# Altium schematic sheet USB_HS.SchDoc
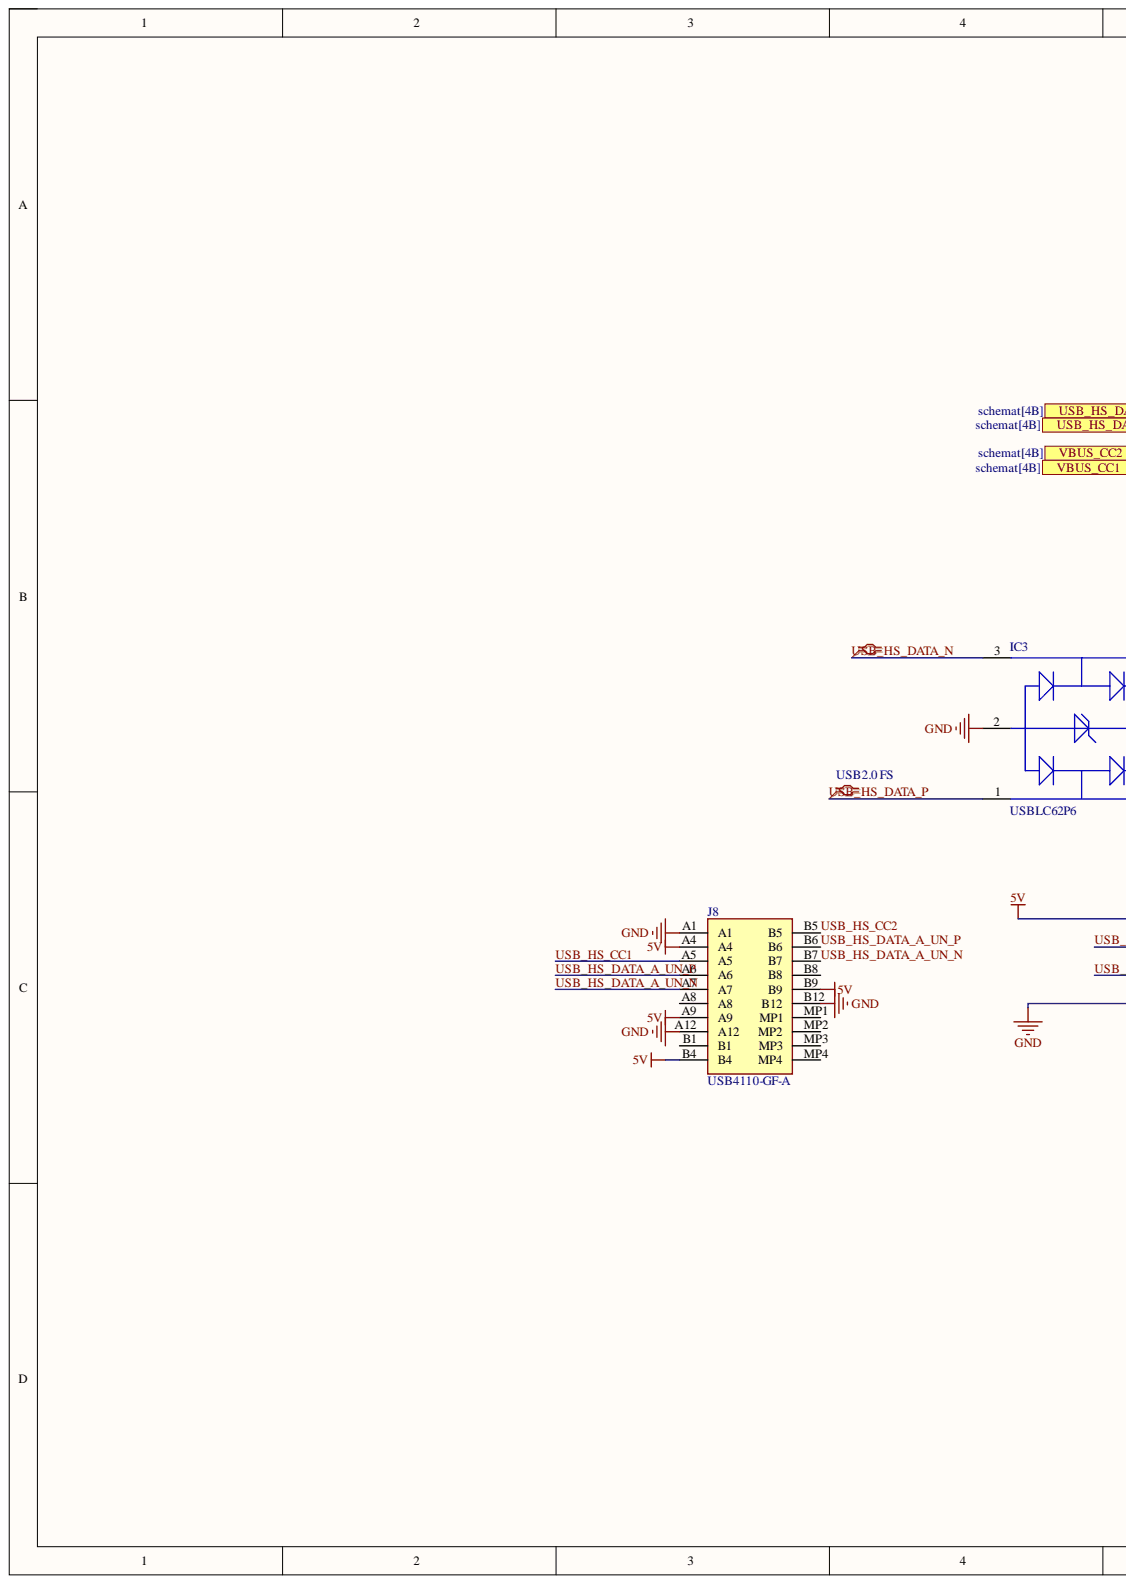
[diagram p0: USB_HS.SchDoc, left]
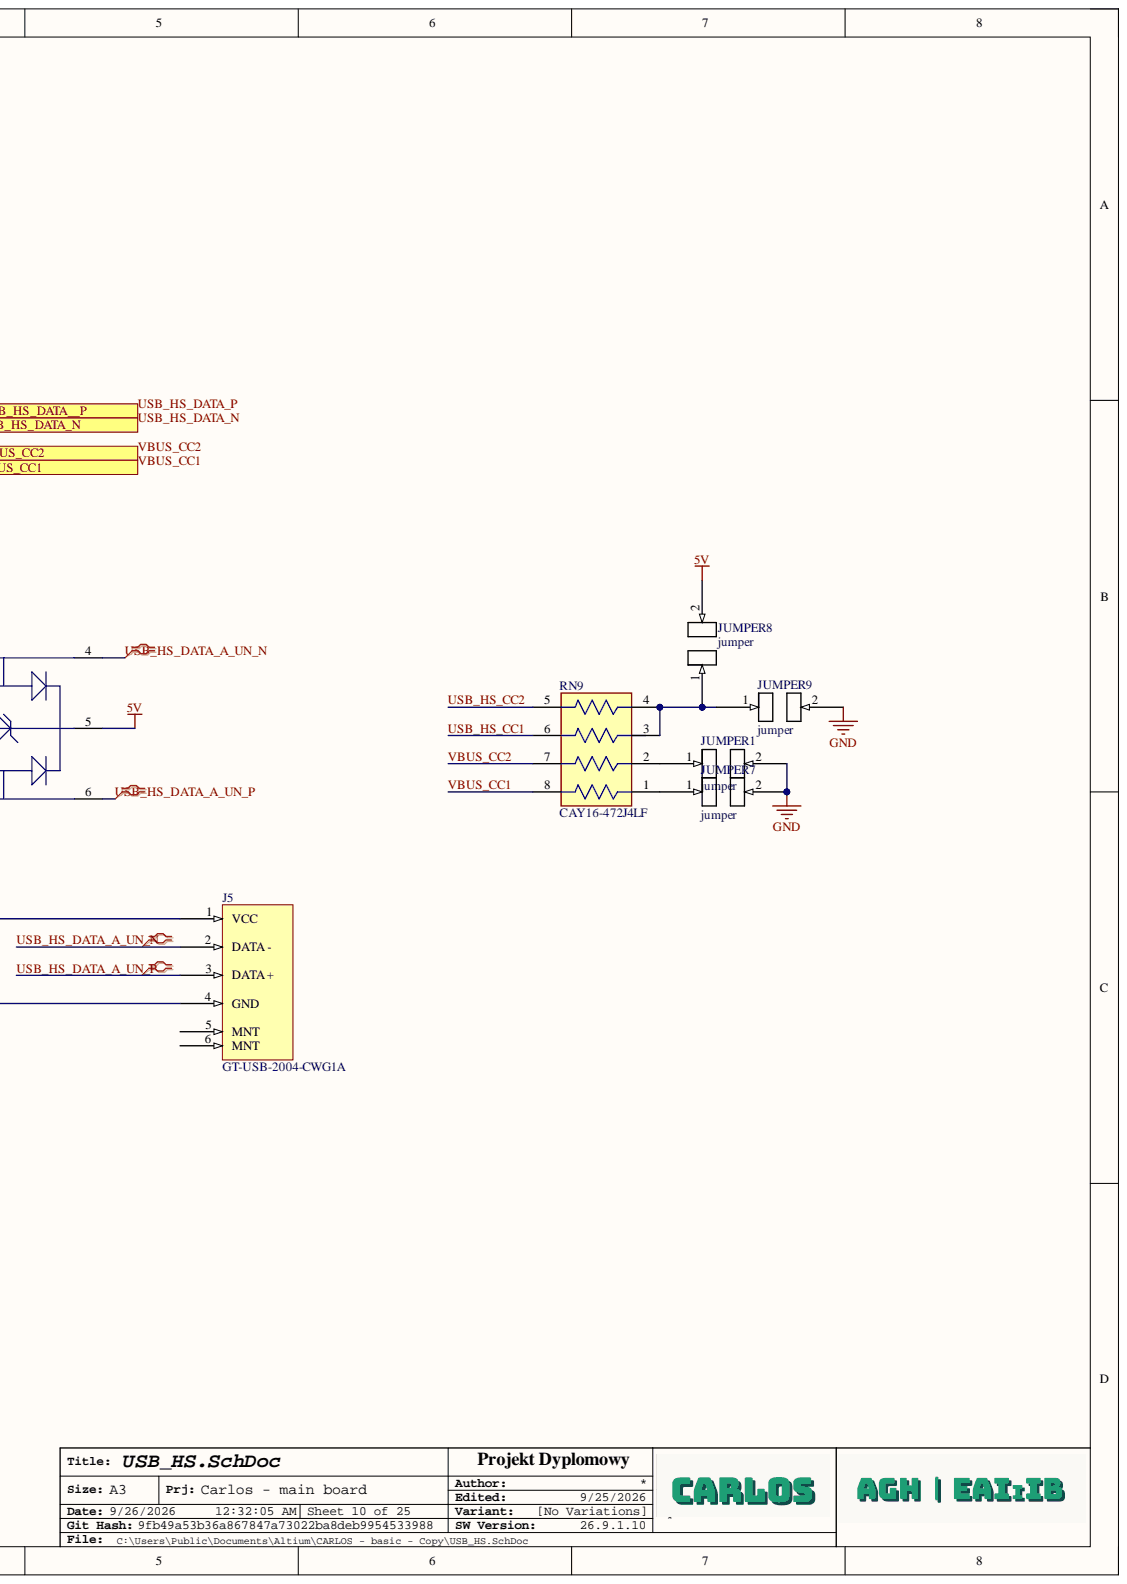
[diagram p1: USB_HS.SchDoc, right]

## Parts
IC3  USBLC62P6  [USBLC62P6]
J5  GT-USB-2004-CWG1A  [New footprint 1]
J8  USB4110-GF-A  [USB4110GFA]
JUMPER1  jumper  [jumper-Footprint-1]
JUMPER7  jumper  [jumper-Footprint-1]
JUMPER8  jumper  [jumper-Footprint-1]
JUMPER9  jumper  [jumper-Footprint-1]
RN9  CAY16-472J4LF  [FP-CAY16-J4-MFG]

## Ports
USB_HS_DATA__P, USB_HS_DATA_N, VBUS_CC1, VBUS_CC2

## Nets
5V: IC3.5 J5.1(VCC) J8.A4 J8.A9 J8.B4 J8.B9 JUMPER8.2
GND: IC3.2 J5.4(GND) J8.A1 J8.A12 J8.B12 JUMPER1.2 JUMPER7.2 JUMPER9.2
USB_HS_CC1: J8.A5 RN9.6
USB_HS_CC2: J8.B5 RN9.5
USB_HS_DATA_A_UN_N: IC3.4 J5.2(DATA_-) J8.A7 J8.B7
USB_HS_DATA_A_UN_P: IC3.6 J5.3(DATA_+) J8.A6 J8.B6
USB_HS_DATA_N: IC3.3 ; port USB_HS_DATA_N
USB_HS_DATA_P: IC3.1 ; port USB_HS_DATA__P
VBUS_CC1: RN9.8 ; port VBUS_CC1
VBUS_CC2: RN9.7 ; port VBUS_CC2
NetJUMPER1_1: JUMPER1.1 RN9.2
NetJUMPER7_1: JUMPER7.1 RN9.1
NetJUMPER8_1: JUMPER8.1 JUMPER9.1 RN9.3 RN9.4

Not connected: J5 5(MNT) 6(MNT); J8 A8 B1 B8 MP1 MP2 MP3 MP4

## Library data of the parts
IC3 = USBLC62P6: Integrated Circuit; Manufacturer_Name: STMicroelectronics; Component Kind: Standard; Datasheet Link: https://datasheet.lcsc.com/szlcsc/STMicroelectronics-USBLC6-2P6_C15999.pdf; Height: 0.6mm; Manufacturer_Part_Number: USBLC62P6
J5 = CMP-002-00129-2: USB TYPE A CONNECTOR SMD 4P; Component Kind: Standard; Library Name: Jakub Sikora
J8 = CMP-002-00092-1: Connector; Manufacturer_Name: GCT (GLOBAL CONNECTOR TECHNOLOGY); Component Kind: Standard; Datasheet Link: https://gct.co/files/drawings/usb4110.pdf; Design Item ID: USB4110-GF-A; Height: 3.26mm; Manufacturer_Part_Number: USB4110-GF-A; Mouser Part Number: 640-USB4110-GF-A; Mouser Price/Stock: https://www.mouser.co.uk/ProductDetail/GCT/USB4110-GF-A?qs=KUoIvG%2F9IlYiZvIXQjyJeA%3D%3D; Source: SamacSys.SchLib; Library Name: Jakub Sikora
JUMPER1 JUMPER7-JUMPER9 = CMP-011-00015-2: Component Kind: Standard; Library Name: Jakub Sikora
RN9 = CMP-07230-000006-1: zamiast tego 4D03WGJ0512T5E; Circuit Type: Isolated; Number of Circuits: 4; Number of Terminals/Pins: 8; Power Per Element: 62.5mW; Power Rating: 248mW; Resistance: 4.7kR; Resistance Tolerance: 5%; Temperature Coefficient: 200ppm/°C; Voltage Rating: 50V; Case Code (Imperial): 1206; Case Code (Metric): 3216; Case/Package: 1206; Component Kind: Standard; Contact Plating: Tin; Depth: 1.6mm; Height: 0.024inch; Length: 3.2mm; Library Name: Altium Content Vault; Max Operating Temperature: 125°C; Military Standard: Not; Min Operating Temperature: -55°C; Mount: Surface Mount; Number of Elements: 4; Number of Pins: 8; Number of Resistors: 4; Package Quantity: 1; Packaging: Tape and Reel; Radiation Hardening: No; REACH SVHC: No SVHC; Resistor Type: Thick Film; Series: CAY16; Technology: THICK FILM; Terminal Pitch: 0.8mm; Termination: Convex; Tolerance: 5%; Voltage Rating (DC): 50V; Width: 0.063inch
